# Altium schematic sheet COMM.SchDoc(COMM)
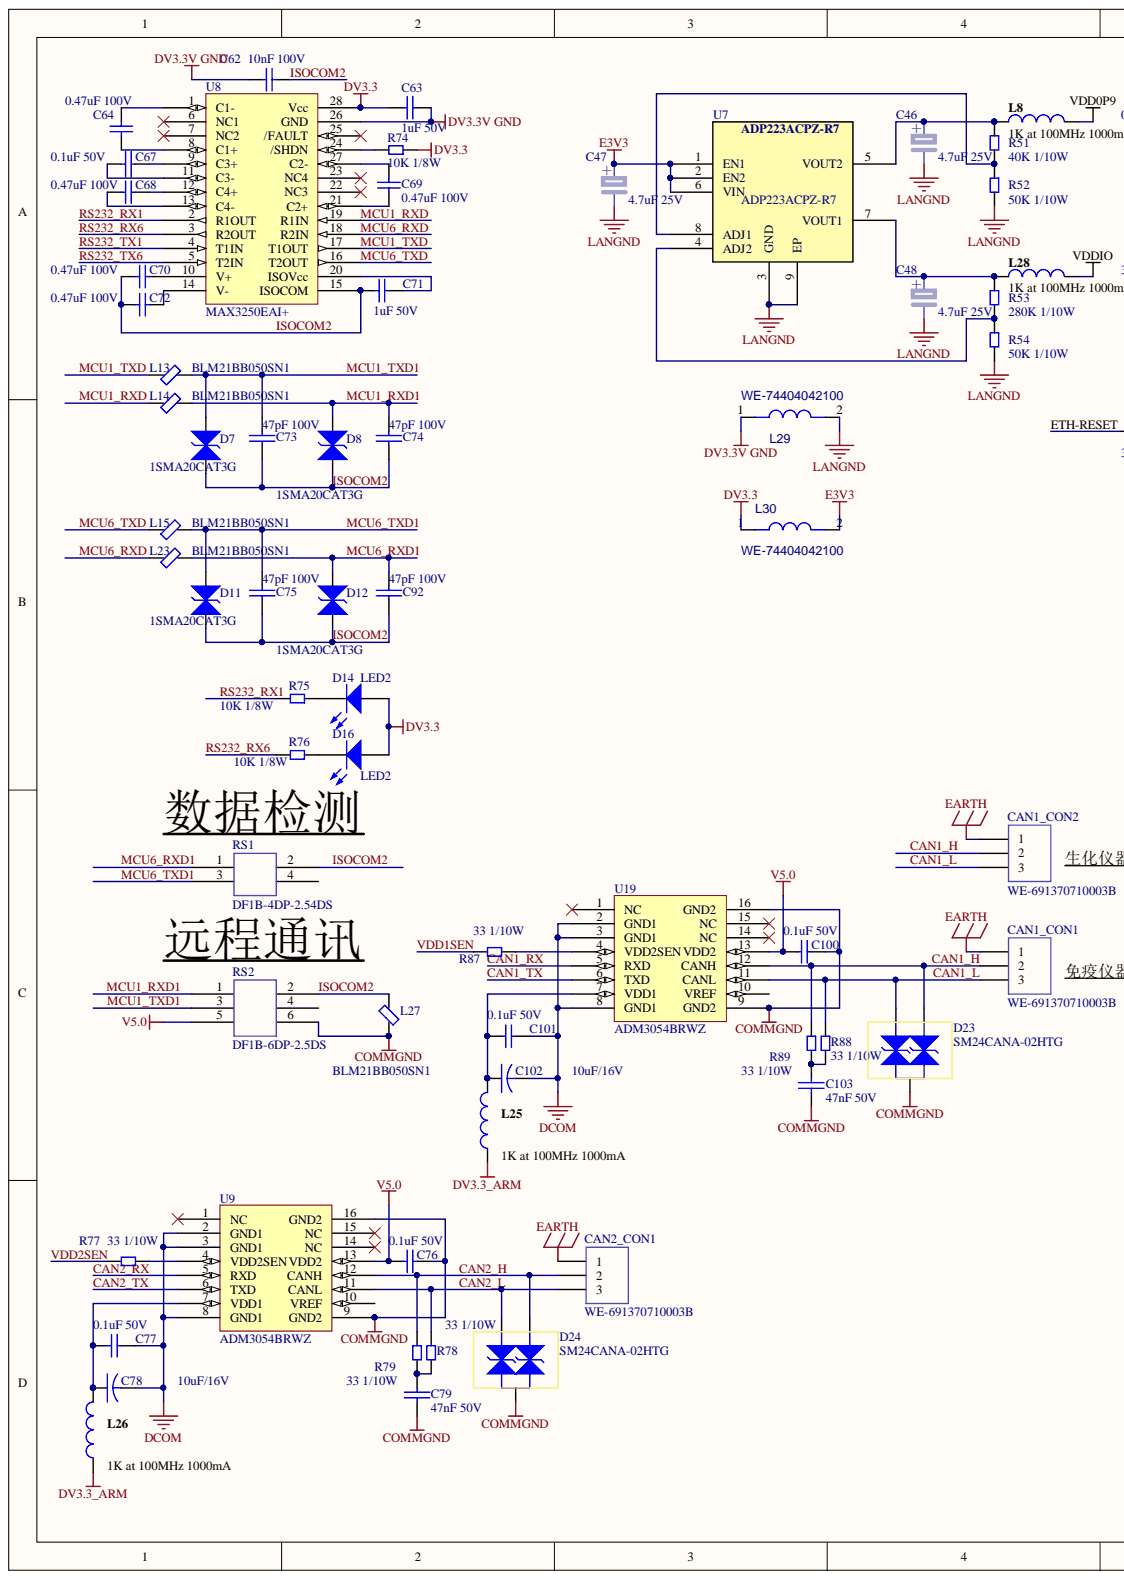
[diagram p0: COMM.SchDoc, left]
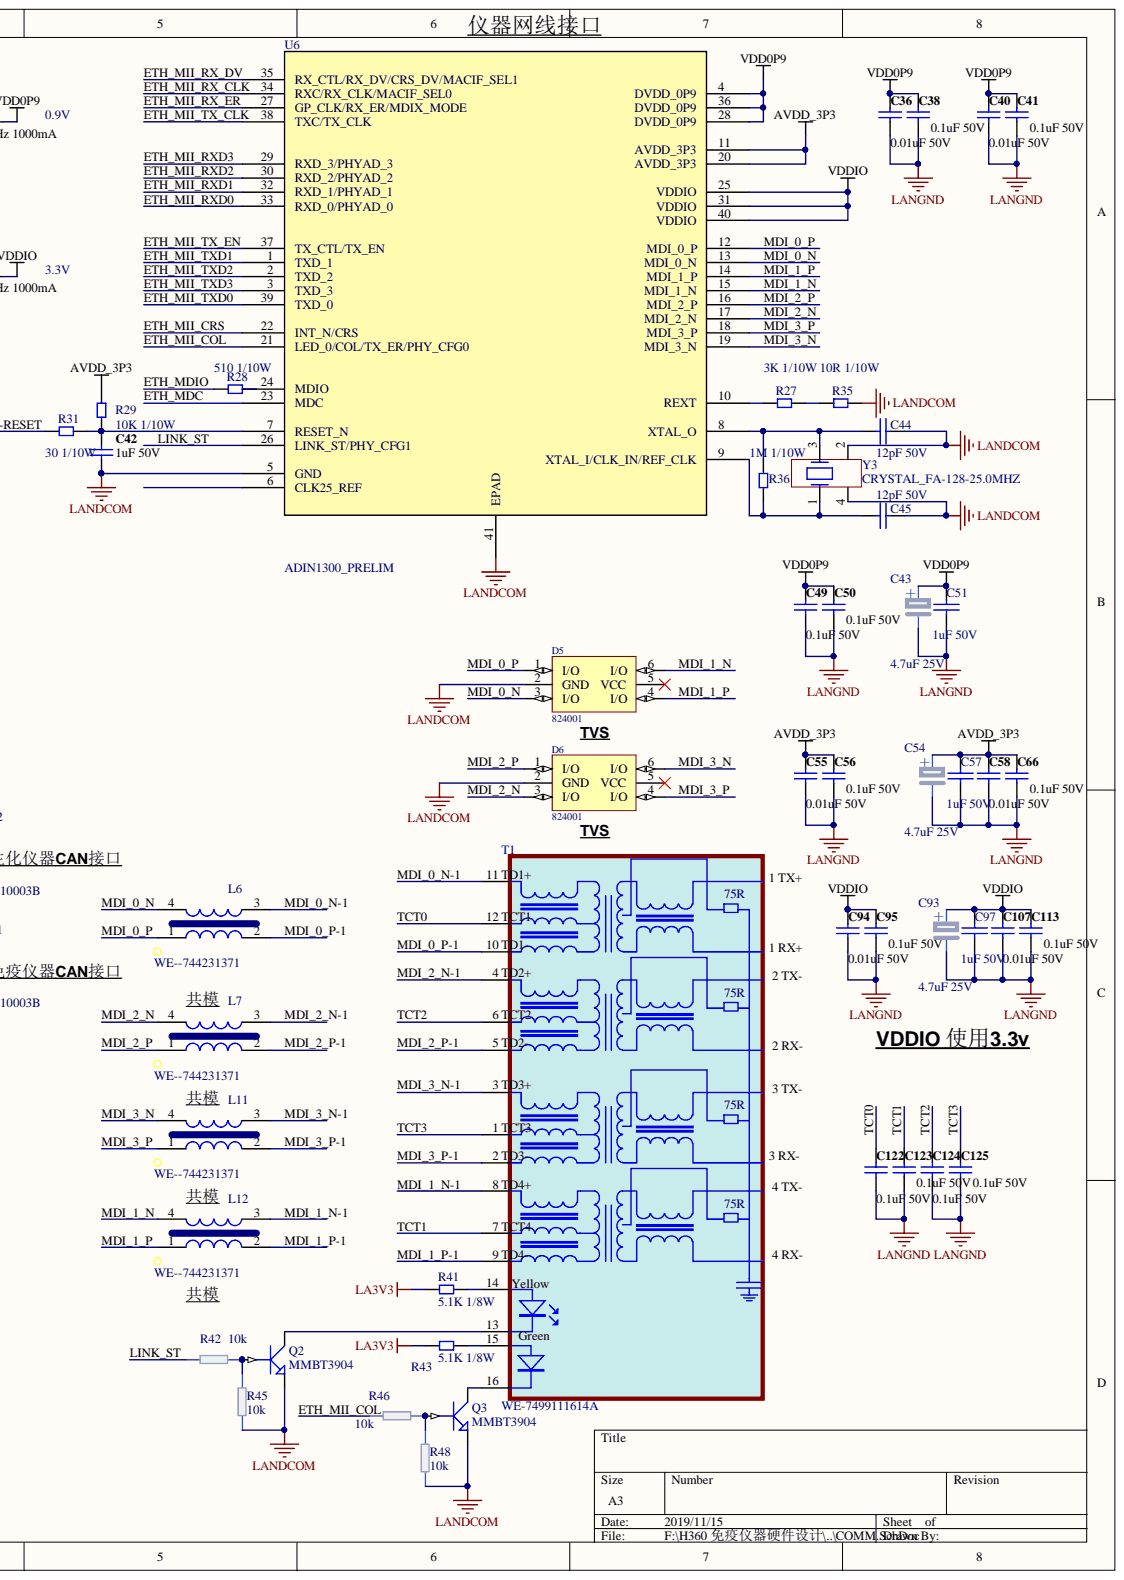
[diagram p1: COMM.SchDoc, right]

## Parts
C36  0.01uF 50V  [C0603_104/50V]
C38  0.1uF 50V  [C0603_104/50V]
C40  0.01uF 50V  [C0603_104/50V]
C41  0.1uF 50V  [C0603_104/50V]
C42  1uF 50V  [C0603_105/50V]
C43  4.7uF 25V  [TAN-A]
C44  12pF 50V  [C0603_120/50V]
C45  12pF 50V  [C0603_120/50V]
C46  4.7uF 25V  [TAN-A]
C47  4.7uF 25V  [TAN-A]
C48  4.7uF 25V  [TAN-A]
C49  0.1uF 50V  [C0603_104/50V]
C50  0.1uF 50V  [C0603_104/50V]
C51  1uF 50V  [C0603_105/50V]
C54  4.7uF 25V  [TAN-A]
C55  0.01uF 50V  [C0603_104/50V]
C56  0.1uF 50V  [C0603_104/50V]
C57  1uF 50V  [C0603_105/50V]
C58  0.01uF 50V  [C0603_104/50V]
C62  10nF 100V  [C0805_103/100V]
C63  1uF 50V  [C0805_105/50V]
C64  0.47uF 100V  [C0805_470/100V]
C66  0.1uF 50V  [C0603_104/50V]
C67  0.1uF 50V  [C0805_104/50V]
C68  0.47uF 100V  [C0805_470/100V]
C69  0.47uF 100V  [C0805_470/100V]
C70  0.47uF 100V  [C0805_470/100V]
C71  1uF 50V  [C0805_105/50V]
C72  0.47uF 100V  [C0805_470/100V]
C73  47pF 100V  [C0805_470/100V]
C74  47pF 100V  [C0805_470/100V]
C75  47pF 100V  [C0805_470/100V]
C76  0.1uF 50V  [C0603_104/50V]
C77  0.1uF 50V  [C0603_104/50V]
C78  10uF/16V  [CAPBCASE_106/16V]
C79  47nF 50V  [C0805_470/100V]
C92  47pF 100V  [C0805_470/100V]
C93  4.7uF 25V  [TAN-A]
C94  0.01uF 50V  [C0603_104/50V]
C95  0.1uF 50V  [C0603_104/50V]
C97  1uF 50V  [C0603_105/50V]
C100  0.1uF 50V  [C0603_104/50V]
C101  0.1uF 50V  [C0603_104/50V]
C102  10uF/16V  [CAPBCASE_106/16V]
C103  47nF 50V  [C0805_470/100V]
C107  0.01uF 50V  [C0603_104/50V]
C113  0.1uF 50V  [C0603_104/50V]
C122  0.1uF 50V  [C0603_104/50V]
C123  0.1uF 50V  [C0603_104/50V]
C124  0.1uF 50V  [C0603_104/50V]
C125  0.1uF 50V  [C0603_104/50V]
CAN1_CON1  WE-691370710003B  [WR-TBL-5.0MM-3PIN_691370710003B]
CAN1_CON2  WE-691370710003B  [WR-TBL-5.0MM-3PIN_691370710003B]
CAN2_CON1  WE-691370710003B  [WR-TBL-5.0MM-3PIN_691370710003B]
D5  824001  [SOT23-6_WE-824001]
D6  824001  [SOT23-6_WE-824001]
D7  1SMA20CAT3G  [SS34_DO124AC_1SMA20CAT3G]
D8  1SMA20CAT3G  [SS34_DO124AC_1SMA20CAT3G]
D11  1SMA20CAT3G  [SS34_DO124AC_1SMA20CAT3G]
D12  1SMA20CAT3G  [SS34_DO124AC_1SMA20CAT3G]
D14  LED2  [LED_0805]
D16  LED2  [LED_0805]
D23  SM24CANA-02HTG  [SOT23_SM24CANA]
D24  SM24CANA-02HTG  [SOT23_SM24CANA]
L6  WE--744231371  [CNSW-0805]
L7  WE--744231371  [CNSW-0805]
L8  1K at 100MHz 1000mA  [0805_BLM21BB050SN1]
L11  WE--744231371  [CNSW-0805]
L12  WE--744231371  [CNSW-0805]
L13  BLM21BB050SN1  [0805_BLM21BB050SN1]
L14  BLM21BB050SN1  [0805_BLM21BB050SN1]
L15  BLM21BB050SN1  [0805_BLM21BB050SN1]
L23  BLM21BB050SN1  [0805_BLM21BB050SN1]
L25  1K at 100MHz 1000mA  [0805_BLM21BB050SN1]
L26  1K at 100MHz 1000mA  [0805_BLM21BB050SN1]
L27  BLM21BB050SN1  [0805_BLM21BB050SN1]
L28  1K at 100MHz 1000mA  [0805_BLM21BB050SN1]
L29  VAL: WE-74404042100  [WE-LQS-SMD4018_74404042022]  (hidden comment: ferrites CMS-BDS3/3/8.9-4S2)
L30  VAL: WE-74404042100  [WE-LQS-SMD4018_74404042022]  (hidden comment: ferrites CMS-BDS3/3/8.9-4S2)
Q2  MMBT3904  [SOT-23_MMBT3904]
Q3  MMBT3904  [SOT-23_MMBT3904]
R27  3K 1/10W  [RES0603]
R28  510 1/10W  [res0603_512]
R29  10K 1/10W  [res0603_103]
R31  30 1/10W  [res0603_200]
R35  10R 1/10W  [RES0603]
R36  1M 1/10W  [RES0603]
R41  5.1K 1/8W  [RES0805_512]
R42  10k  [RES0603_104]
R43  5.1K 1/8W  [RES0805_512]
R45  10k  [RES0603_104]
R46  10k  [RES0603_104]
R48  10k  [RES0603_104]
R51  40K 1/10W  [RES0603]
R52  50K 1/10W  [RES0603]
R53  280K 1/10W  [RES0603]
R54  50K 1/10W  [RES0603]
R74  10K 1/8W  [RES0805_103]
R75  10K 1/8W  [RES0805_103]
R76  10K 1/8W  [RES0805_103]
R77  33 1/10W  [RES0603_100]
R78  33 1/10W  [RES0603_100]
R79  33 1/10W  [RES0603_100]
R87  33 1/10W  [RES0603_100]
R88  33 1/10W  [RES0603_100]
R89  33 1/10W  [RES0603_100]
RS1  DF1B-4DP-2.54DS  [DF1B-4DP-2.54DS]
RS2  DF1B-6DP-2.5DS  [DF1B-6DP-2.54DS]
T1  WE-7499111614A  [RJ45-WE-LAN-SCH3-HOLE3]
U6  ADIN1300_PRELIM  [CP_40_26]
U7  ADP223ACPZ-R7  [CP_8_10]
U8  MAX3250EAI+  [SSOP28]
U9  ADM3054BRWZ  [SOW16_ADM3054BRWZ]
U19  ADM3054BRWZ  [SOW16_ADM3054BRWZ]
Y3  CRYSTAL_FA-128-25.0MHZ  [FA-128_25MHZ]

## Nets
AVDD_3P3: C54.1 C55.2 C56.2 C57.1 C58.2 C66.2 R29.1 U6.11(AVDD_3P3) U6.20(AVDD_3P3)
COMMGND: C76.1 C79.1 C100.1 C103.1 D23.3 D24.3 L27.1 RS2.6 U9.9(GND2) U9.16(GND2) U19.9(GND2) U19.16(GND2)
DCOM: C77.1 C78.2 C101.1 C102.2 U9.2(GND1) U9.3(GND1) U9.8(GND1) U19.2(GND1) U19.3(GND1) U19.8(GND1)
DV3.3: C63.1 D14.1 D16.1 L30.1 R74.1 U8.28(Vcc)
DV3.3_ARM: L25.1 L26.1
DV3.3V GND: C62.2 C63.2 L29.1 U8.26(GND)
E3V3: C47.1 L30.2 U7.1(EN1) U7.2(EN2) U7.6(VIN)
EARTH: CAN1_CON1.1 CAN1_CON2.1 CAN2_CON1.1
LA3V3: R41.2 R43.2
LANDCOM: C42.1 C44.2 C45.1 D5.2(GND) D6.2(GND) Q2.2 Q3.2 R35.2 R45.1 R48.1 U6.5(GND) U6.41(EPAD) Y3.2 Y3.4
LANGND: C36.1 C38.1 C40.1 C41.1 C43.2 C46.2 C47.2 C48.2 C49.1 C50.1 C51.2 C54.2 C55.1 C56.1 C57.2 C58.1 C66.1 C93.2 C94.1 C95.1 C97.2 C107.1 C113.1 C122.1 C123.1 C124.1 C125.1 L29.2 R52.2 R54.2 U7.3(GND) U7.9(EP)
V5.0: C76.2 C100.2 RS2.5 U9.13(VDD2) U19.13(VDD2)
VDD0P9: C36.2 C38.2 C40.2 C41.2 C43.1 C49.2 C50.2 C51.1 L8.2 U6.4(DVDD_0P9) U6.28(DVDD_0P9) U6.36(DVDD_0P9)
VDDIO: C93.1 C94.2 C95.2 C97.1 C107.2 C113.2 L28.2 U6.25(VDDIO) U6.31(VDDIO) U6.40(VDDIO)
CAN1_H: CAN1_CON1.2 CAN1_CON2.2 D23.1 R89.1 U19.12(CANH)
CAN1_L: CAN1_CON1.3 CAN1_CON2.3 D23.2 R88.1 U19.11(CANL)
CAN1_RX: U19.5(RXD)
CAN1_TX: U19.6(TXD)
CAN2_H: CAN2_CON1.2 D24.1 R79.1 U9.12(CANH)
CAN2_L: CAN2_CON1.3 D24.2 R78.1 U9.11(CANL)
CAN2_RX: U9.5(RXD)
CAN2_TX: U9.6(TXD)
ETH-RESET: R31.2
ETH_MDC: U6.23(MDC)
ETH_MDIO: R28.2
ETH_MII_COL: R46.1 U6.21(LED_0/COL/TX_ER/PHY_CFG0)
ETH_MII_CRS: U6.22(INT_N/CRS)
ETH_MII_RX_CLK: U6.34(RXC/RX_CLK/MACIF_SEL0)
ETH_MII_RX_DV: U6.35(RX_CTL/RX_DV/CRS_DV/MACIF_SEL1)
ETH_MII_RX_ER: U6.27(GP_CLK/RX_ER/MDIX_MODE)
ETH_MII_RXD0: U6.33(RXD_0/PHYAD_0)
ETH_MII_RXD1: U6.32(RXD_1/PHYAD_1)
ETH_MII_RXD2: U6.30(RXD_2/PHYAD_2)
ETH_MII_RXD3: U6.29(RXD_3/PHYAD_3)
ETH_MII_TX_CLK: U6.38(TXC/TX_CLK)
ETH_MII_TX_EN: U6.37(TX_CTL/TX_EN)
ETH_MII_TXD0: U6.39(TXD_0)
ETH_MII_TXD1: U6.1(TXD_1)
ETH_MII_TXD2: U6.2(TXD_2)
ETH_MII_TXD3: U6.3(TXD_3)
ISOCOM2: C62.1 C70.2 C71.2 C72.2 C73.2 C74.2 C75.2 C92.2 D7.1 D8.1 D11.1 D12.1 L27.2 RS1.2 RS2.2 U8.15(ISOCOM)
LINK_ST: R42.1 U6.26(LINK_ST/PHY_CFG1)
MCU1_RXD: L14.1 U8.19(R1IN)
MCU1_RXD1: C74.1 D8.2 L14.2 RS2.1
MCU1_TXD: L13.1 U8.17(T1OUT)
MCU1_TXD1: C73.1 D7.2 L13.2 RS2.3
MCU6_RXD: L23.1 U8.18(R2IN)
MCU6_RXD1: C92.1 D12.2 L23.2 RS1.1
MCU6_TXD: L15.1 U8.16(T2OUT)
MCU6_TXD1: C75.1 D11.2 L15.2 RS1.3
MDI_0_N: D5.3(I/O) L6.4 U6.13(MDI_0_N)
MDI_0_N-1: L6.3 T1.11
MDI_0_P: D5.1(I/O) L6.1 U6.12(MDI_0_P)
MDI_0_P-1: L6.2 T1.10
MDI_1_N: D5.6(I/O) L12.4 U6.15(MDI_1_N)
MDI_1_N-1: L12.3 T1.8
MDI_1_P: D5.4(I/O) L12.1 U6.14(MDI_1_P)
MDI_1_P-1: L12.2 T1.9
MDI_2_N: D6.3(I/O) L7.4 U6.17(MDI_2_N)
MDI_2_N-1: L7.3 T1.4
MDI_2_P: D6.1(I/O) L7.1 U6.16(MDI_2_P)
MDI_2_P-1: L7.2 T1.5
MDI_3_N: D6.6(I/O) L11.4 U6.19(MDI_3_N)
MDI_3_N-1: L11.3 T1.3
MDI_3_P: D6.4(I/O) L11.1 U6.18(MDI_3_P)
MDI_3_P-1: L11.2 T1.2
RS232_RX1: R75.2 U8.2(R1OUT)
RS232_RX6: R76.2 U8.3(R2OUT)
RS232_TX1: U8.4(T1IN)
RS232_TX6: U8.5(T2IN)
TCT0: C122.2 T1.12
TCT1: C123.2 T1.7
TCT2: C124.2 T1.6
TCT3: C125.2 T1.1
VDD1SEN: R87.2
VDD2SEN: R77.2
NetC42_2: C42.2 R29.2 R31.1 U6.7(RESET_N)
NetC44_1: C44.1 R36.1 U6.8(XTAL_O) Y3.3
NetC45_2: C45.2 R36.2 U6.9(XTAL_I/CLK_IN/REF_CLK) Y3.1
NetC46_1: C46.1 L8.1 R51.1 U7.5(VOUT2)
NetC48_1: C48.1 L28.1 R53.1 U7.7(VOUT1)
NetC64_1: C64.1 U8.1(C1-)
NetC64_2: C64.2 U8.8(C1+)
NetC67_1: C67.1 U8.9(C3+)
NetC67_2: C67.2 U8.11(C3-)
NetC68_1: C68.1 U8.12(C4+)
NetC68_2: C68.2 U8.13(C4-)
NetC69_1: C69.1 U8.21(C2+)
NetC69_2: C69.2 U8.27(C2-)
NetC70_1: C70.1 U8.10(V+)
NetC71_1: C71.1 U8.20(ISOVcc)
NetC72_1: C72.1 U8.14(V-)
NetC77_2: C77.2 C78.1 L26.2 U9.7(VDD1)
NetC79_2: C79.2 R78.2 R79.2
NetC101_2: C101.2 C102.1 L25.2 U19.7(VDD1)
NetC103_2: C103.2 R88.2 R89.2
NetD14_2: D14.2 R75.1
NetD16_2: D16.2 R76.1
NetQ2_1: Q2.1 R42.2 R45.2
NetQ2_3: Q2.3 T1.13(Green)
NetQ3_1: Q3.1 R46.2 R48.2
NetQ3_3: Q3.3 T1.16
NetR27_1: R27.1 U6.10(REXT)
NetR27_2: R27.2 R35.1
NetR28_1: R28.1 U6.24(MDIO)
NetR41_1: R41.1 T1.14(Yellow)
NetR43_1: R43.1 T1.15
NetR51_2: R51.2 R52.1 U7.8(ADJ1)
NetR53_2: R53.2 R54.1 U7.4(ADJ2)
NetR74_2: R74.2 U8.24(/SHDN)
NetR77_1: R77.1 U9.4(VDD2SEN)
NetR87_1: R87.1 U19.4(VDD2SEN)

Not connected: D5 5(VCC); D6 5(VCC); RS1 4; RS2 4; U6 6(CLK25_REF); U8 6(NC1) 7(NC2) 22(NC3) 23(NC4) 25(/FAULT); U9 1(NC) 10(VREF) 14(NC) 15(NC); U19 1(NC) 10(VREF) 14(NC) 15(NC)

## Library data of the parts
C36 C38 C40 C41 C49 C50 C55 C56 C58 C66 C94 C95 C107 C113 C122-C125 = D-101-0080: Capacitor, Ceramic, 0.1uF 10% 16V 0603 X7R; Polarity: Non-Polarized; Capacitance: 0.1 uF; Category: Capacitor; Component Kind: Standard; Dielectric: X7R; Library Name: AltiumDesignLibrary.DesignLib; Mounting Technology: Surface Mount; Rated Voltage: 16 V; Status: Production; Technology: Ceramic; Tolerance: 10 %
C42 = D-101-0077: Capacitor, Ceramic, 10nF 10% 50V 0603 X7R; Polarity: Non-Polarized; Rated Voltage: 16 V; Capacitance: 10 nF; Category: Capacitor; Component Kind: Standard; Dielectric: X7R; Library Name: 2018.328PCBlib.PcbLib; Mounting Technology: Surface Mount; Status: Production; Technology: Ceramic; Tolerance: 10 %
C43 C46-C48 C54 C93 = CAPACITOR: Component Kind: Standard; Description: Capacitor; Library Name: 3DGeneral.SCHLIB
C44 C45 = CAP: Capacitor, Ceramic, 10nF 10% 16V 0603 X7R; Component Kind: Standard
C51 C57 C97 = CAP: 'SAMSUNG  MULTILAYER  CERAMIC 5%  0603 X7R; Component Kind: Standard
C62-C64 C68-C72 = Cap Semi: SAMSUNG  MULTILAYER  CERAMIC 5%; Value: 105; Component Kind: Standard; LatestRevisionDate: 29-May-2009; LatestRevisionNote: IPC-7351 Footprint Added.; Library Name: 2018.328PCBlib.PcbLib; PackageDescription: Chip Capacitor; PackageReference: C1206; Published: 8-Jun-2000; Signal Integrity: Cap Semi; Simulation: CAP; Publisher: Altium Limited
C67 = CAP: Capacitor, Ceramic, 10uF 5% 10V 0805 (2012) X7R; Component Kind: Standard
C73-C75 C92 = CAP: SAMSUNG  MULTILAYER  CERAMIC 5%; Component Kind: Standard
C76 C77 C100 C101 = Cap Semi: Capacitor, Ceramic, 10uF 5% 50V 0805 (2012) X5R; Value: 105; Component Kind: Standard; LatestRevisionDate: 29-May-2009; LatestRevisionNote: IPC-7351 Footprint Added.; Library Name: Miscellaneous Devices.IntLib; PackageDescription: Chip Capacitor; PackageReference: C1206; Published: 8-Jun-2000; Signal Integrity: Cap Semi; Simulation: CAP; Publisher: Altium Limited
C78 C102 = CAPACITOR: Capacitor, tantalum, 22 μF, TAJ_B; Component Kind: Standard
C79 C103 = CAP: Capacitor, Ceramic, 47nF 5% 50V 0805 (2012) X5R; Component Kind: Standard
CAN1_CON1 CAN1_CON2 CAN2_CON1 = Header 3: WR-TBL Series 370B-5.00mm; Component Kind: Standard; LatestRevisionDate: 17-Jul-2002; LatestRevisionNote: Re-released for DXP Platform.; Library Name: AD_SCH.SCHLIB; Signal Integrity: Connector; Publisher: Altium Limited
D5 D6 = SP0504SHTG: TVS Diode Arrays WE-TVS; AEMS Code: 1300330; Component Kind: Standard; Library Name: 2015.10.1.SCHLIB
D7 D8 D11 D12 = DIODE OVERVOLTAGE C: 400 Watt Peak Power Zener Transient Voltage Suppressors; Component Kind: Standard
D14 D16 = LED2: Typical GREEN, AMBER GaAs LED; Component Kind: Standard; LatestRevisionDate: 17-Jul-2002; LatestRevisionNote: Re-released for DXP Platform.; Library Name: Miscellaneous Devices.IntLib; PackageDescription: SM LED; 2 Flat Leads; PackageReference: 3.2X1.6X1.1; PackageVersion: Feb-2002; Published: 8-Jun-2000; Simulation: LED2; Publisher: Altium Limited
D23 D24 = TVS Diode Array: Littelfuse SM24CANA Series 200W  TVS Diode Array; Component Kind: Standard; Library Name: CommBoard.SCHLIB
L6 L7 L11 L12 = WE-CNSW: SMD Common Mode Line Filter  Z=2X370Ohm RDC=370Ohm I=280mA WE--744231371; Component Kind: Standard; Library Name: 2015.10.1.SCHLIB
L8 L25 L26 L28 = D-102-0002: Inductor, Ferrite Bead 1K@100MHz 300mA 0805 (2012) BMB2A1000LN2; Inductance: nH; Category: Inductor; Component Kind: Standard; Library Name: AltiumDesignLibrary.DesignLib; Max Input Current: 300 mA; Mounting Technology: Surface Mount; Status: Production
L13-L15 L23 L27 = FERRITE BEAD: On-Board Type (DC) EMI Suppression Filters; Component Kind: Standard; Library Name: 2018.328PCBlib.PcbLib
L29 L30 = ferrites CMS-BDS3/3/8.9-4S2: Bottom: 20; DESIG: SMD BEAD; DEV: FILTRE_BDS3_3_8_9; FAB: FERROXCUBE; Right: 50; Component Kind: Standard; Library Name: Sch-3.SCHLIB; REF: BDS3/3/8.9-4S2
Q2 Q3 = NPN1: NPN Transistor; Component Kind: Standard
R27 R29 R35 R41 R43 R51-R54 R74-R76 = RES2: YAGEO Chip  1%; Component Kind: Standard
  R41 R43: Library Name: 2018.328PCBlib.PcbLib
R28 R31 R36 R77-R79 R87-R89 = RES2: YAGEO Chip Res 1/10W 1%; Component Kind: Standard
R42 R45 R46 R48 = Res2: YAGEO Chip Res 1/10W 1%; Component Kind: Standard; LatestRevisionDate: 17-Jul-2002; LatestRevisionNote: Re-released for DXP Platform.; Library Name: 3DGeneral.SCHLIB; PackageReference: AXIAL-0.4; Published: 8-Jun-2000; Signal Integrity: Res; Simulation: RESISTOR; Publisher: Altium Limited
RS1 = CONN_2*2: Component Kind: Standard; Library Name: Connector.SchLib
RS2 = CONN_3*2: Component Kind: Standard; Library Name: Connector.SchLib
T1 = 7499111614A: WE-RJ45 LAN-Transformer WE-RJ45LAN 10/100/1000 BaseT; Component Kind: Standard; Library Name: 2015.10.1.SCHLIB
U6 = ADIN1300_PRELIM: Imported; Component Kind: Standard; Library Name: 2015.10.1.SCHLIB; RefDes: RefDes; Type: Type; Value: Value
U7 = ADP223ACPZ-R7: Imported; Component Kind: Standard; Library Name: 2015.10.1.SCHLIB; RefDes: RefDes; Type: Type; Value: Value
U8 = MAX3250: ±50V Isolated, 3.0V to 5.5V, 250kbps, 2 Tx/2 Rx, RS-232 Transceiver; Component Kind: Standard; Library Name: Comunication board.SCHLIB
U9 U19 = ADM3054: 5 kV rms Signal Isolated High Speed CAN Transceiver with Bus Protection; Component Kind: Standard; Library Name: 2017.4.24 MainBoard.SchLib
Y3 = CRYSTAL_FA-128: FA-128 (MHz 频率范围晶体单元 超小型超薄型表贴式(SMD)); Component Kind: Standard; Library Name: 2015.10.1.SCHLIB
